# Altium schematic sheet RP2350_Power.SchDoc
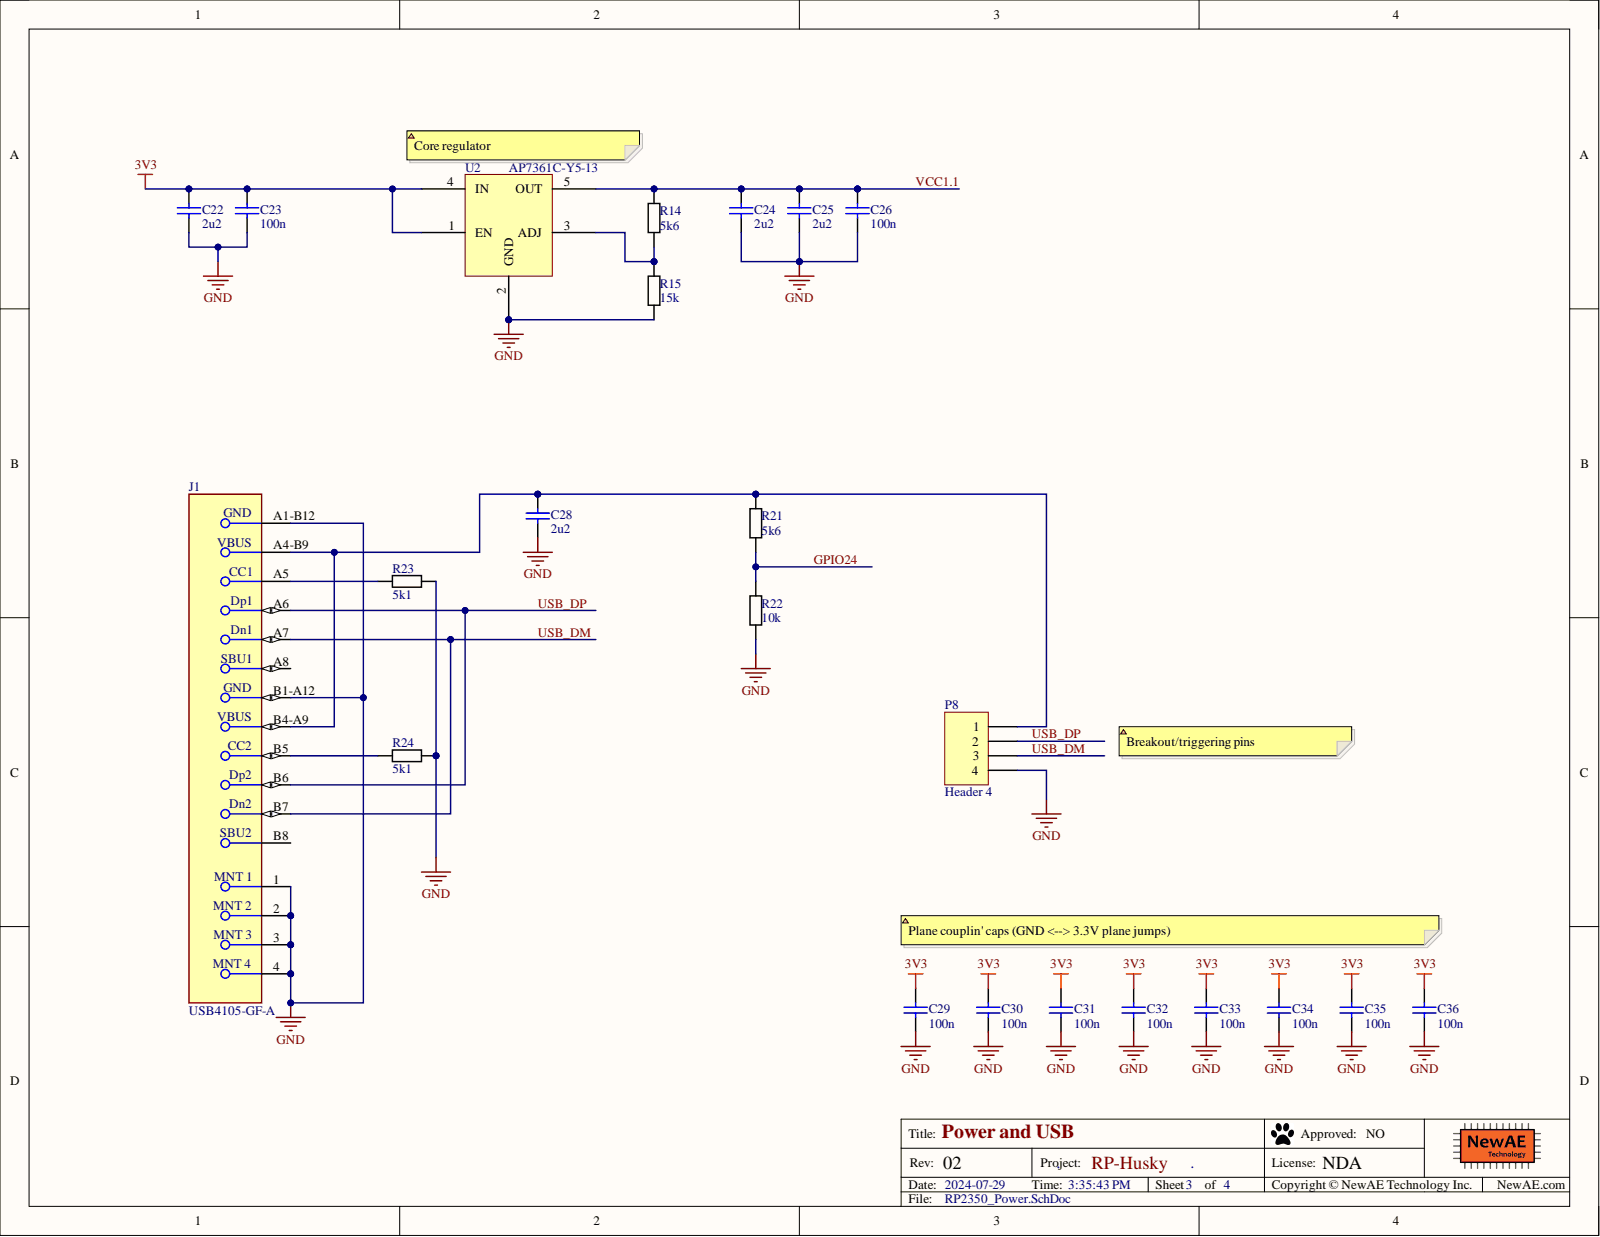
[diagram p0: RP2350_Power.SchDoc]

## Parts
C22  2u2  [0603]
C23  100n  [0402]
C24  2u2  [0603]
C25  2u2  [0603]
C26  100n  [0402]
C28  2u2  [0603]
C29  100n  [0402]
C30  100n  [0402]
C31  100n  [0402]
C32  100n  [0402]
C33  100n  [0402]
C34  100n  [0402]
C35  100n  [0402]
C36  100n  [0402]
J1  USB4105-GF-A  [FP-USB4105-GF-A-MFG]
P8  Header 4  [HDR1X4]
R14  5k6  [0603]
R15  15k  [0603]
R21  5k6  [0603]
R22  10k  [0603]
R23  5k1  [0603]
R24  5k1  [0603]
U2  AP7361C-Y5-13  [SOT89-5]

## Nets
3V3: C22.1 C23.1 C29.1 C30.1 C31.1 C32.1 C33.1 C34.1 C35.1 C36.1 U2.1(EN) U2.4(IN)
GND: C22.2 C23.2 C24.2 C25.2 C26.2 C28.2 C29.2 C30.2 C31.2 C32.2 C33.2 C34.2 C35.2 C36.2 J1.1 J1.2 J1.3 J1.4 J1.A1-B12 J1.B1-A12 P8.4 R15.2 R22.2 R23.2 R24.2 U2.2(GND)
GPIO24: R21.2 R22.1
USB_DM: J1.A7 J1.B7 P8.3
USB_DP: J1.A6 J1.B6 P8.2
VCC1.1: C24.1 C25.1 C26.1 R14.1 U2.5(OUT)
NetC28_1: C28.1 J1.A4-B9 J1.B4-A9 P8.1 R21.1
NetJ1_A5: J1.A5 R23.1
NetJ1_B5: J1.B5 R24.1
NetR14_2: R14.2 R15.1 U2.3(ADJ)

Not connected: J1 A8 B8

## Library data of the parts
C22 C24 C25 C28 = CCHIP-2u2-0603: 2u2, 0603; Manufacture 1: Samsung; Manufacture Part Number 1: CL10B225KP8NNNC; Case-Metric: 1608; Component Group: Capacitor; Database Table Name: main; Rated Voltage: 10VDC; Case-EIA: 0603; Component Kind: Standard; Component Type: Standard; Library Name: NewAE_AltiumLib.DBLib; Mounting Technology: SMT; Part Number: CCHIP-2u2-0603; Pin Count: 2; Supplier 1: Digi-Key; Supplier Part Number 1: 1276-1134-1-ND; Value: 2u2
C23 C26 C29-C36 = CCHIP-100n-0402: 100n, 0402; Manufacture Part Number 1: CGA2B3X7R1V104K050BB; Component Group: Capacitor; Database Table Name: main; Case-EIA: 0402; Component Kind: Standard; Component Type: Standard; Library Name: NewAE_AltiumLib.DBLib; Mounting Technology: SMT; Part Number: CCHIP-100n-0402; Pin Count: 2; Supplier 1: Digi-Key; Supplier Part Number 1: 445-6901-1-ND; Value: 100n
J1 = CMP-245163-000001-1: CONN RCPT USB2.0 TYPEC 5A; Manufacturer: GCT; Conn Orientation: Right Angle; Current Rating: 5/6.25/1.25/0.25A; Is Female: yes; Number Of Contacts: 16; Voltage Rating: 20V; Automotive: No; Category: Conn; Component Kind: Standard; ComponentLink2Description: Datasheet; ComponentLink2URL: https://gct.co/files/drawings/usb4105.pdf; Contact Material: Gold,Nickel; Device Class L1: Connectors; Device Class L2: USB Connectors; Device Class L3: unset; Digikey Description: CONN RCPT USB2.0 TYPEC 5A; Height: 3.46mm; Lead Free: Yes; Library Name: Altium Content Vault; Manufacturer Part Number: USB4105-GF-A; Octopart: https://octopart.com/usb4105-gf-a-gct-106627878; Package: 0; RoHS: TRUE; Temperature: -40°C to +85°C; Temperature Range High: +85°C; Temperature Range Low: -40°C; Usb Standard: USB 2.0
P8 = Header 4: Header, 4-Pin; Component Kind: Standard; LatestRevisionDate: 17-Jul-2002; LatestRevisionNote: Re-released for DXP Platform.; Library Name: Miscellaneous Connectors.IntLib; Signal Integrity: Connector; Publisher: Altium Limited
R14 R21 = RCHIP-5K6-0603: 5k6 res, 0603, 1%, 1/10W; Manufacture 1: Yageo; Manufacture Part Number 1: RC0603FR-075K6L; Case-Metric: 1608; Component Group: Resistor; Database Table Name: main; Rated Power: 0.1W; Rated Voltage: 75VDC; Case-EIA: 0603; Component Kind: Standard; Component Type: Standard; Library Name: NewAE_AltiumLib.DBLib; Mounting Technology: SMT; Part Number: RCHIP-5K6-0603; Pin Count: 2; Supplier 1: Digi-Key; Supplier Part Number 1: 311-5.60KHRCT-ND; Temperature Range: -55C to 155C; Tolerance: 1%; Value: 5k6
R15 = RCHIP-15k-0603: 15k res, 0603, 1%, 1/10W; Manufacture 1: Yageo; Manufacture Part Number 1: RC0603FR-0715KL; Case-Metric: 1608; Component Group: Resistor; Database Table Name: main; Rated Power: 0.1W; Rated Voltage: 75VDC; Case-EIA: 0603; Component Kind: Standard; Component Type: Standard; Library Name: NewAE_AltiumLib.DBLib; Mounting Technology: SMT; Part Number: RCHIP-15K-0603; Pin Count: 2; Supplier 1: Digi-Key; Supplier Part Number 1: 311-15.0KHRCT-ND; Temperature Range: -55C to 155C; Tolerance: 1%; Value: 15k
R22 = RCHIP-10K-0603: 10k res, 0603, 1%, 1/10W; Manufacture 1: Yageo; Manufacture Part Number 1: RC0603FR-0710KL; Case-Metric: 1608; Component Group: Resistor; Database Table Name: main; Rated Power: 0.1W; Rated Voltage: 75VDC; Case-EIA: 0603; Component Kind: Standard; Component Type: Standard; Library Name: NewAE_AltiumLib.DBLib; Mounting Technology: SMT; Part Number: RCHIP-10K-0603; Pin Count: 2; Supplier 1: Digi-Key; Supplier Part Number 1: 311-10.0KHRCT-ND; Temperature Range: -55C to 155C; Tolerance: 1%; Value: 10k
R23 R24 = RCHIP-5K1-0603: 5k1 res, 0603, 1%, 1/10W; Manufacture 1: Yageo; Manufacture Part Number 1: RC0603FR-075K1L; Case-Metric: 1608; Component Group: Resistor; Database Table Name: main; Rated Power: 0.1W; Rated Voltage: 75VDC; Case-EIA: 0603; Component Kind: Standard; Component Type: Standard; Library Name: NewAE_AltiumLib.DBLib; Mounting Technology: SMT; Part Number: RCHIP-5K1-0603; Pin Count: 2; Supplier 1: Digi-Key; Supplier Part Number 1: 311-5.10KHRCT-ND; Temperature Range: -55C to 155C; Tolerance: 1%; Value: 5k1
U2 = AP7361C-Y5-13: Regulator, Adj, LDO, 1A; Manufacture 1: Diodes Inc; Component Group: Analog IC; Database Table Name: main; PP Material Stack: 0; Component Kind: Standard; Component Type: Standard; Library Name: NewAE_AltiumLib.DBLib; Manufacture Part Number 1: AP7361C-Y5-13; Part Number: AP7361C-Y5-13; Pin Count: 5; PP Rotation: 0; PP Rotation1: 0; Supplier 1: Digi-Key; Supplier Part Number 1: AP7361C-Y5-13DICT-ND
